# Altium schematic sheet Sheet1.SchDoc(Sheet1)
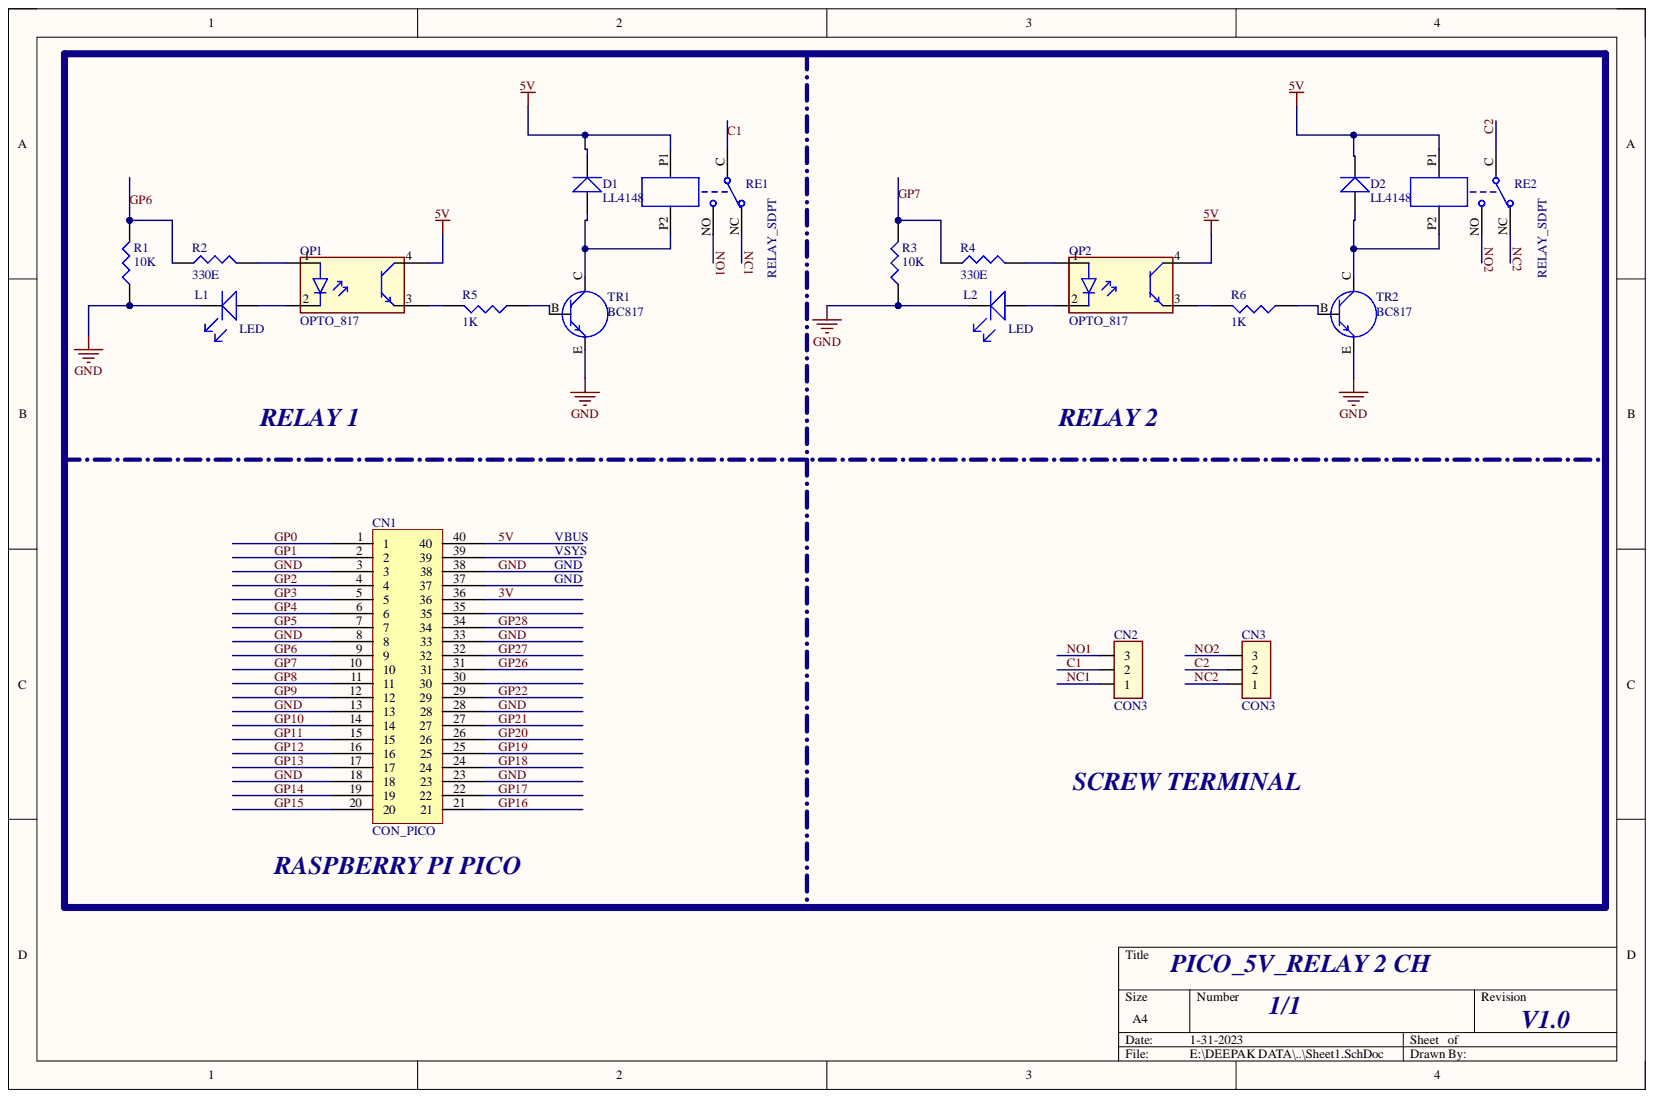
[diagram p0: Sheet1.SchDoc]

## Parts
CN1  CON_PICO  [CON40_PICO_TOP FEMALE]
CN2  CON3  [CON3_3.5]
CN3  CON3  [CON3_3.5]
D1  LL4148  [MINI_MELF_SOD80]
D2  LL4148  [MINI_MELF_SOD80]
L1  LED  [LED_0603_neg]
L2  LED  [LED_0603_neg]
OP1  OPTO_817  [OPTO_817_SMD]
OP2  OPTO_817  [OPTO_817_SMD]
R1  10K  [0603]
R2  330E  [0603]
R3  10K  [0603]
R4  330E  [0603]
R5  1K  [0603]
R6  1K  [0603]
RE1  RELAY_SDPT  [RELAY_SDPT]
RE2  RELAY_SDPT  [RELAY_SDPT]
TR1  BC817  [547_SOT23]
TR2  BC817  [547_SOT23]

## Nets
3V: CN1.36
5V: CN1.40 D1.K D2.K OP1.4 OP2.4 RE1.P1 RE2.P1
C1: CN2.2 RE1.C
C2: CN3.2 RE2.C
GND: CN1.3 CN1.8 CN1.13 CN1.18 CN1.23 CN1.28 CN1.33 CN1.38 L1.K L2.K R1.1 R3.1 TR1.E TR2.E
GP0: CN1.1
GP1: CN1.2
GP2: CN1.4
GP3: CN1.5
GP4: CN1.6
GP5: CN1.7
GP6: CN1.9 R1.2 R2.1
GP7: CN1.10 R3.2 R4.1
GP8: CN1.11
GP9: CN1.12
GP10: CN1.14
GP11: CN1.15
GP12: CN1.16
GP13: CN1.17
GP14: CN1.19
GP15: CN1.20
GP16: CN1.21
GP17: CN1.22
GP18: CN1.24
GP19: CN1.25
GP20: CN1.26
GP21: CN1.27
GP22: CN1.29
GP26: CN1.31
GP27: CN1.32
GP28: CN1.34
NC1: CN2.1 RE1.NC
NC2: CN3.1 RE2.NC
NO1: CN2.3 RE1.NO
NO2: CN3.3 RE2.NO
NetD1_A: D1.A RE1.P2 TR1.C
NetD2_A: D2.A RE2.P2 TR2.C
NetL1_A: L1.A OP1.2
NetL2_A: L2.A OP2.2
NetOP1_1: OP1.1 R2.2
NetOP1_3: OP1.3 R5.2
NetOP2_1: OP2.1 R4.2
NetOP2_3: OP2.3 R6.2
NetR5_1: R5.1 TR1.B
NetR6_1: R6.1 TR2.B

Not connected: CN1 30 35 37 39

## Library data of the parts
CN1 = CON40_PICO FEMALE: Component Kind: Standard; Library Name: dxp_pasl_lib.IntLib
D1 D2 = DIODE: Component Kind: Standard; Library Name: dxp_pasl_lib.IntLib
R1-R6 = RES: Component Kind: Standard; Library Name: dxp_pasl_lib.IntLib
TR1 TR2 = TRANSISTER_NPN: Component Kind: Standard; Library Name: dxp_pasl_lib.IntLib
CN2 CN3 = CON3: Component Kind: Standard; Library Name: dxp_pasl_lib.IntLib
L1 L2 = LED: Component Kind: Standard; Library Name: dxp_pasl_lib.IntLib
OP1 OP2 = OPTO_817: Component Kind: Standard; Library Name: dxp_pasl_lib.IntLib
RE1 RE2 = RELAY_SDPT: Component Kind: Standard; Library Name: dxp_pasl_lib.IntLib
